# Altium schematic sheet XENON_PWR.SchDoc
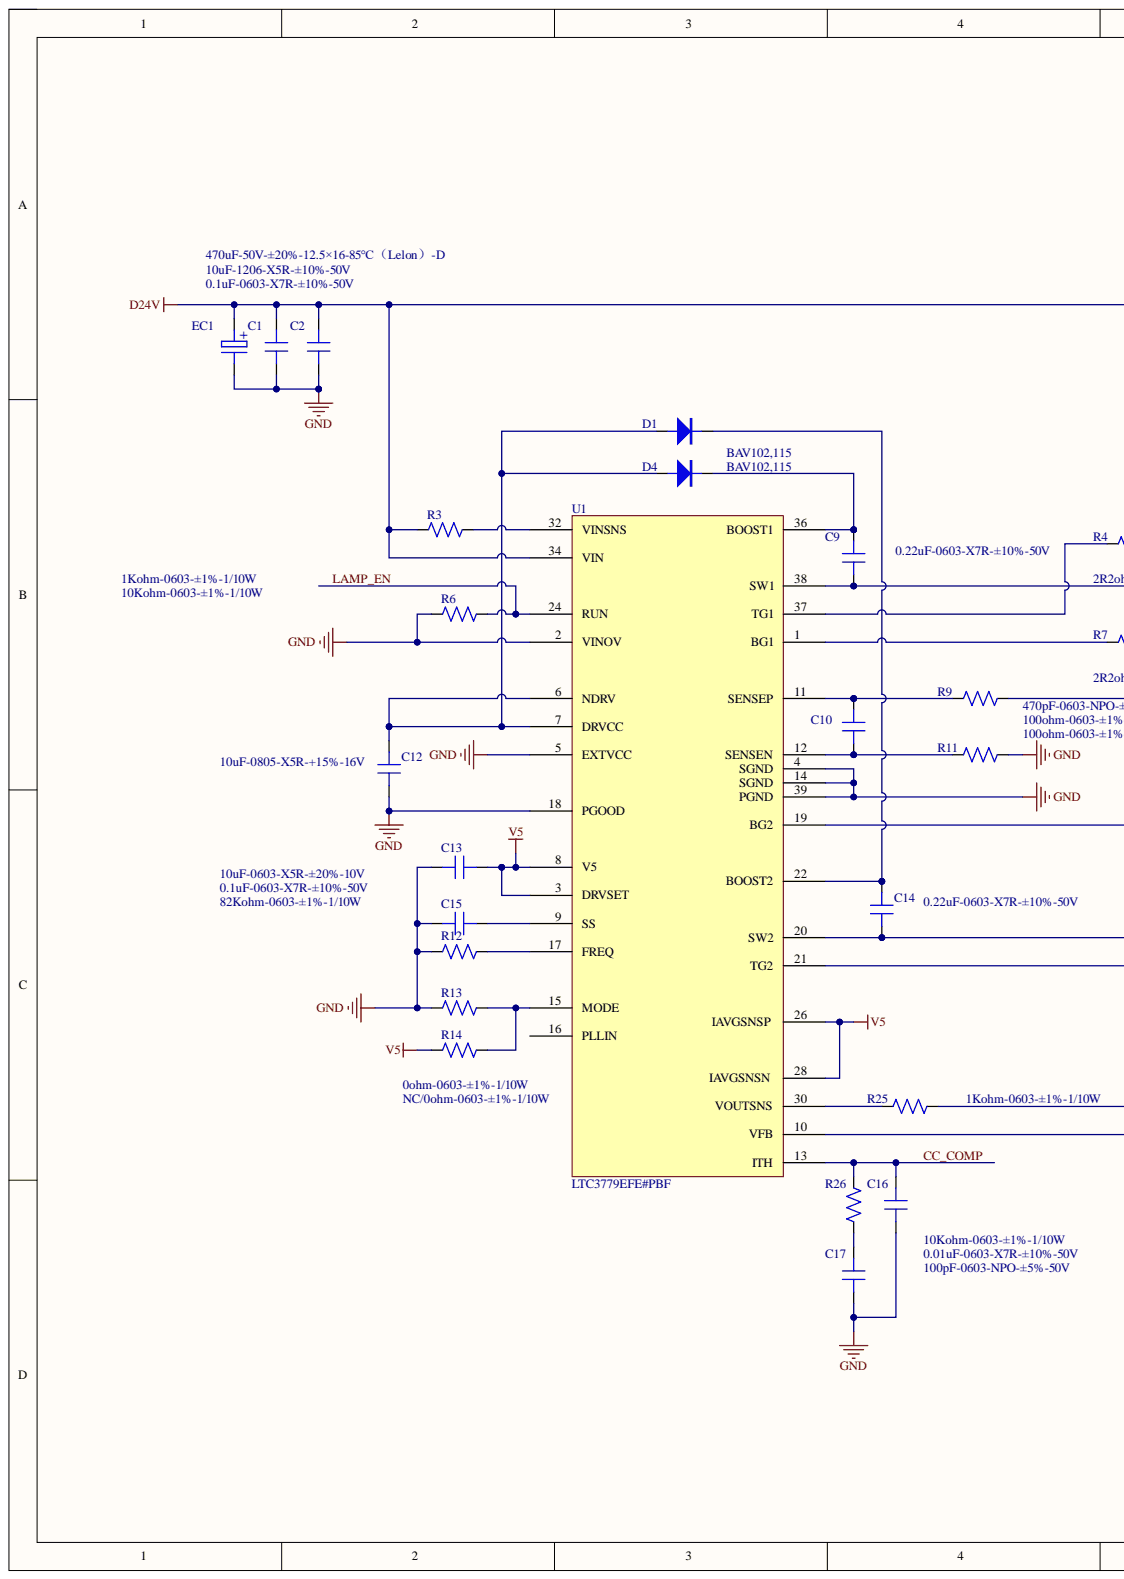
[diagram p0: XENON_PWR.SchDoc, left]
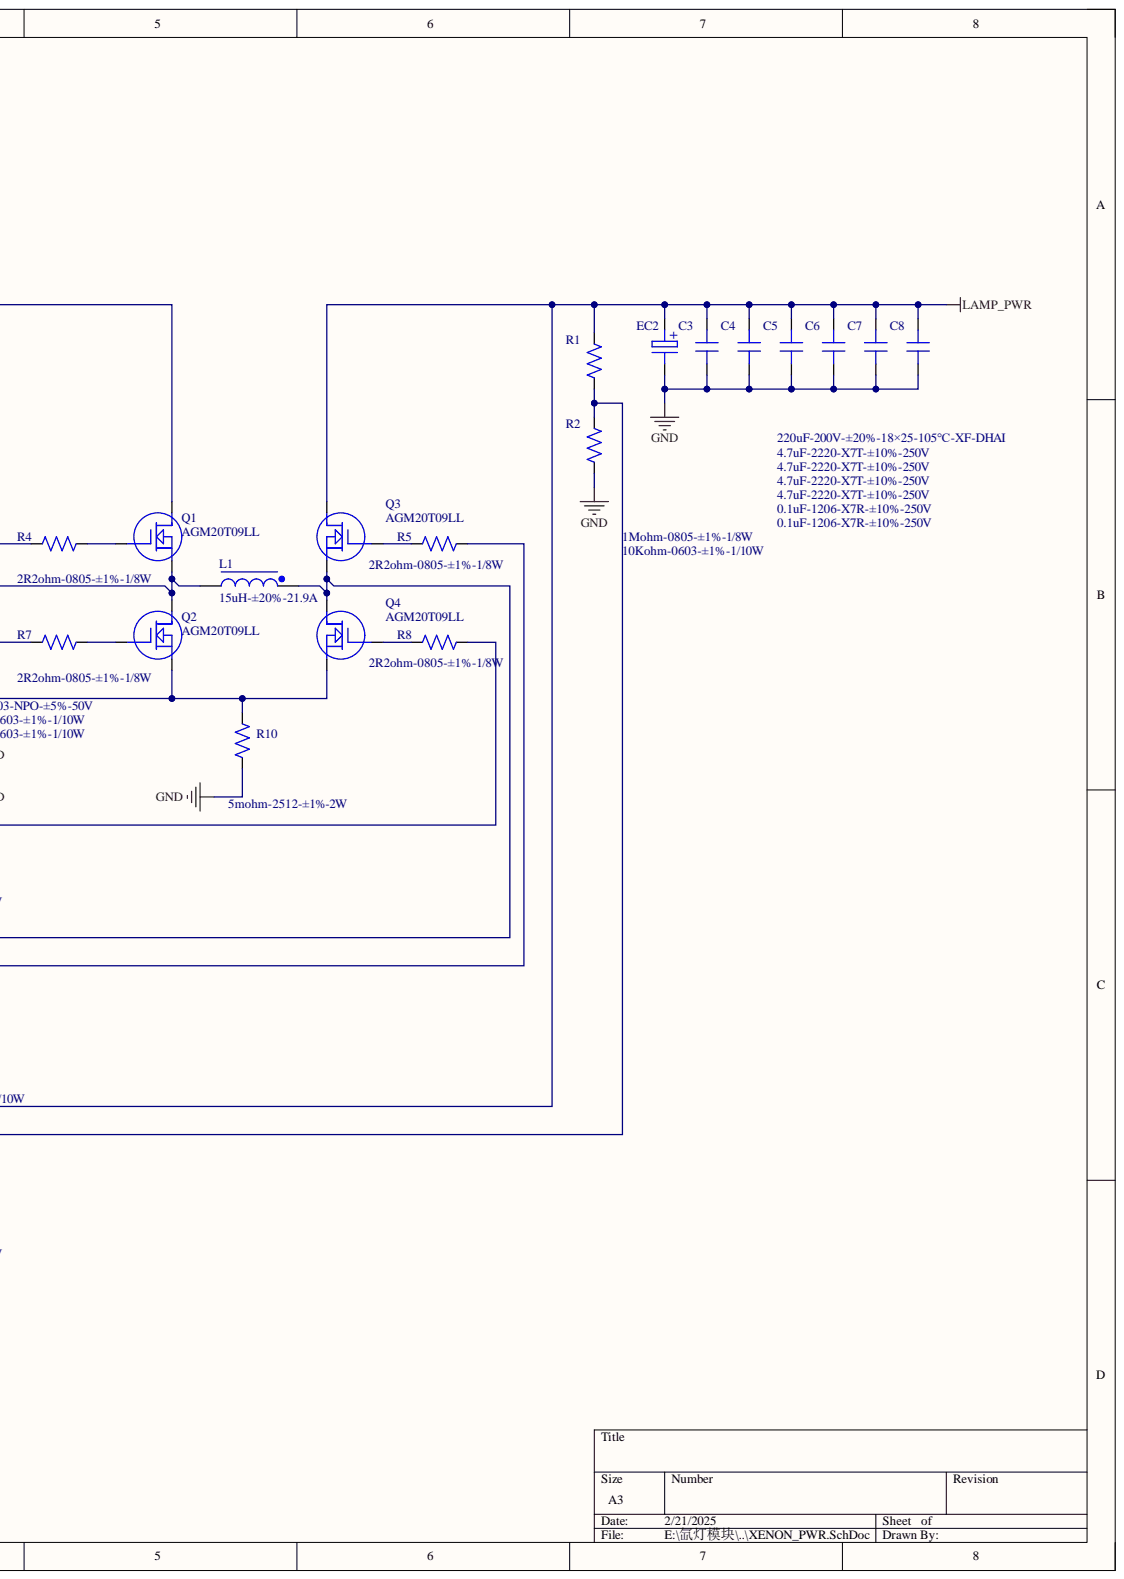
[diagram p1: XENON_PWR.SchDoc, right]

## Parts
C1  10uF-1206-X5R-±10%-50V  [C1206]
C2  0.1uF-0603-X7R-±10%-50V  [C0603]
C3  4.7uF-2220-X7T-±10%-250V  [C2220]
C4  4.7uF-2220-X7T-±10%-250V  [C2220]
C5  4.7uF-2220-X7T-±10%-250V  [C2220]
C6  4.7uF-2220-X7T-±10%-250V  [C2220]
C7  0.1uF-1206-X7R-±10%-250V  [C1206]
C8  0.1uF-1206-X7R-±10%-250V  [C1206]
C9  0.22uF-0603-X7R-±10%-50V  [C0603]
C10  470pF-0603-NPO-±5%-50V  [C0603]
C12  10uF-0805-X5R-+15%-16V  [C0805]
C13  10uF-0603-X5R-±20%-10V  [C0603]
C14  0.22uF-0603-X7R-±10%-50V  [C0603]
C15  0.1uF-0603-X7R-±10%-50V  [C0603]
C16  100pF-0603-NPO-±5%-50V  [C0603]
C17  0.01uF-0603-X7R-±10%-50V  [C0603]
D1  BAV102,115  [DIODE-S0805]
D4  BAV102,115  [DIODE-S0805]
EC1  470uF-50V-±20%-12.5×16-85℃（Lelon）-D  [EC(12.5*16)-S]
EC2  220uF-200V-±20%-18×25-105℃-XF-DHAI  [EC(18*25)-V7.5]
L1  15uH-±20%-21.9A  [SER2918-Power Inductor]
Q1  AGM20T09LL  [TOLL-8]
Q2  AGM20T09LL  [TOLL-8]
Q3  AGM20T09LL  [TOLL-8]
Q4  AGM20T09LL  [TOLL-8]
R1  1Mohm-0805-±1%-1/8W  [R0805]
R2  10Kohm-0603-±1%-1/10W  [R0603]
R3  1Kohm-0603-±1%-1/10W  [R0603]
R4  2R2ohm-0805-±1%-1/8W  [R0805]
R5  2R2ohm-0805-±1%-1/8W  [R0805]
R6  10Kohm-0603-±1%-1/10W  [R0603]
R7  2R2ohm-0805-±1%-1/8W  [R0805]
R8  2R2ohm-0805-±1%-1/8W  [R0805]
R9  100ohm-0603-±1%-1/10W  [R0603]
R10  5mohm-2512-±1%-2W  [R2512]
R11  100ohm-0603-±1%-1/10W  [R0603]
R12  82Kohm-0603-±1%-1/10W  [R0603]
R13  0ohm-0603-±1%-1/10W  [R0603]
R14  NC/0ohm-0603-±1%-1/10W  [R0603]
R25  1Kohm-0603-±1%-1/10W  [R0603]
R26  10Kohm-0603-±1%-1/10W  [R0603]
U1  LTC3779EFE#PBF  [SO-38(31)/0.5]

## Nets
D24V: C1.2 C2.2 EC1.1 Q1.D R3.2 U1.34(VIN)
GND: C1.1 C2.1 C3.1 C4.1 C5.1 C6.1 C7.1 C8.1 C12.1 C13.1 C15.1 C16.1 C17.1 EC1.2 EC2.2 R2.2 R6.2 R10.2 R11.2 R12.1 R13.1 U1.2(VINOV) U1.4(SGND) U1.5(EXTVCC) U1.14(SGND) U1.18(PGOOD) U1.39(PGND)
LAMP_PWR: C3.2 C4.2 C5.2 C6.2 C7.2 C8.2 EC2.1 Q3.D R1.1 R25.2
V5: C13.2 R14.1 U1.3(DRVSET) U1.8(V5) U1.26(IAVGSNSP) U1.28(IAVGSNSN)
CC_COMP: C16.2 R26.2 U1.13(ITH)
LAMP_EN: R6.1 U1.24(RUN)
NetC9_1: C9.1 L1.2 Q1.S Q2.D U1.38(SW1)
NetC9_2: C9.2 D4.K U1.36(BOOST1)
NetC10_1: C10.1 R9.1 U1.11(SENSEP)
NetC10_2: C10.2 R11.1 U1.12(SENSEN)
NetC12_2: C12.2 D1.A D4.A U1.6(NDRV) U1.7(DRVCC)
NetC14_1: C14.1 L1.1 Q3.S Q4.D U1.20(SW2)
NetC14_2: C14.2 D1.K U1.22(BOOST2)
NetC15_2: C15.2 U1.9(SS)
NetC17_2: C17.2 R26.1
NetQ1_G: Q1.G R4.2
NetQ2_G: Q2.G R7.2
NetQ2_S: Q2.S Q4.S R9.2 R10.1
NetQ3_G: Q3.G R5.1
NetQ4_G: Q4.G R8.1
NetR1_2: R1.2 R2.1 U1.10(VFB)
NetR3_1: R3.1 U1.32(VINSNS)
NetR4_1: R4.1 U1.37(TG1)
NetR5_2: R5.2 U1.21(TG2)
NetR7_1: R7.1 U1.1(BG1)
NetR8_2: R8.2 U1.19(BG2)
NetR12_2: R12.2 U1.17(FREQ)
NetR13_2: R13.2 R14.2 U1.15(MODE)
NetR25_1: R25.1 U1.30(VOUTSNS)

Not connected: U1 16(PLLIN)

## Library data of the parts
C1 = 10uF-1206-X5R-±10%-50V-D: Component Kind: Standard; Library Name: RCL.SchLib
C2 C15 = 0.1uF-0603-X7R-±10%-50V-D: CAP; Component Kind: Standard; Library Name: RCL.SchLib
C3-C6 = 4.7uF-2220-X7T-±10%-250V-D: FE2HX475M251LGL; MODEL: C5750X7T2E225KT000N; Component Kind: Standard; Library Name: RCL.SchLib
C7 C8 = 0.1uF-1206-X7R-±10%-250V-D: GRM31CR72E104KW03L; Component Kind: Standard; Library Name: RCL.SchLib
C9 C14 = 0.22uF-0603-X7R-±10%-50V-D: CAP; Component Kind: Standard; Library Name: RCL.SchLib
C10 = 470pF-0603-NPO-±5%-50V-D: Component Kind: Standard; Library Name: RCL.SchLib
C12 = 10uF-0805-X5R-+15%-16V-D: Component Kind: Standard; Library Name: RCL.SchLib
C13 = 10uF-0603-X5R-±20%-10V-D: Component Kind: Standard; Library Name: RCL.SchLib
C16 = 100pF-0603-NPO-±5%-50V-D: Component Kind: Standard; Library Name: RCL.SchLib
C17 = 0.01uF-0603-X7R-±10%-50V-D: Component Kind: Standard; Library Name: RCL.SchLib
D1 D4 = BAV102,115-D: 200V,250mA; Component Kind: Standard; Library Name: Semiconductor.SchLib
EC1 = 470uF-50V-±20%-12.5×16-85℃（Lelon）-D: VE-471M1HTR-1316; Component Kind: Standard; Library Name: RCL.SchLib
EC2 = 220uF-200V-±20%-18×25-105℃-XF-DHAI: 电解电容   EKXG201ELL221MM25S; Component Kind: Standard; Library Name: RCL.SchLib
L1 = 15uH-±20%-21.9A,FC-SE2918-150M-D: Component Kind: Standard; Library Name: RCL.SchLib
Q1-Q4 = AGM20T09LL-D: NMOS，200V，RDSON=8.3mohm，110A; Component Kind: Standard; Library Name: xenon_drv.SchLib
R1 = 1Mohm-0805-±1%-1/8W-D: RES; Component Kind: Standard; Library Name: RCL.SchLib
R2 R6 R26 = 10Kohm-0603-±1%-1/10W-D: Component Kind: Standard; Library Name: RCL.SchLib
R3 R25 = 1Kohm-0603-±1%-1/10W-D: Component Kind: Standard; Library Name: RCL.SchLib
R4 R5 R7 R8 = 2R2ohm-0805-±1%-1/8W-D: Component Kind: Standard; Library Name: RCL.SchLib
R9 R11 = 100ohm-0603-±1%-1/10W-D: Component Kind: Standard; Library Name: RCL.SchLib
R10 = 5mohm-2512-±1%-2W-D: Component Kind: Standard; Library Name: RCL.SchLib
R12 = 82Kohm-0603-±1%-1/10W-D: Component Kind: Standard; Library Name: RCL.SchLib
R13 R14 = 0ohm-0603-±1%-1/10W-D: Component Kind: Standard; Library Name: RCL.SchLib
U1 = LTC3779EFE#PBF-D: 150V 4-Switch BUCK-BOOST DC-DC Controller; Component Kind: Standard; Library Name: Semiconductor.SchLib
